# Altium schematic sheet SystemController.SchDoc(SystemController)
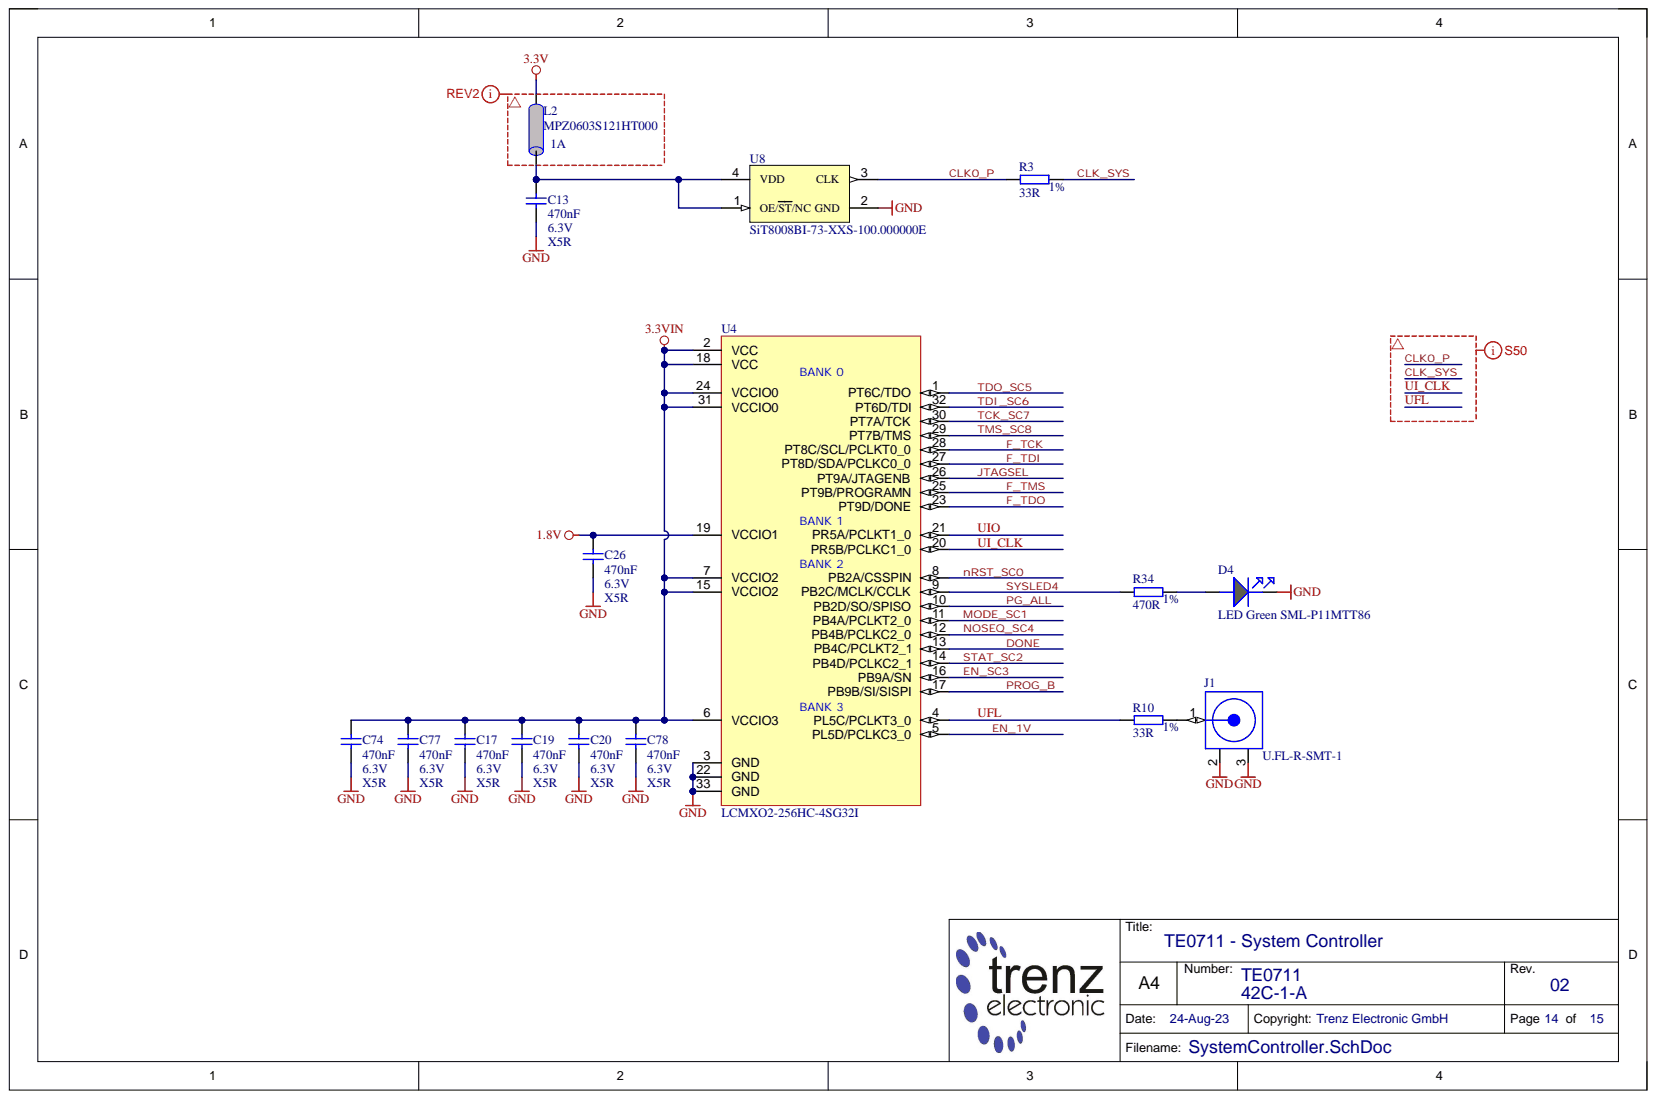
[diagram p0: SystemController.SchDoc]

## Parts
C13  470nF  Technology: X5R  Voltage: 6.3V  [CAPC0603X33N_V]
C17  470nF  Technology: X5R  Voltage: 6.3V  [CAPC0603X33N_V]
C19  470nF  Technology: X5R  Voltage: 6.3V  [CAPC0603X33N_V]
C20  470nF  Technology: X5R  Voltage: 6.3V  [CAPC0603X33N_V]
C26  470nF  Technology: X5R  Voltage: 6.3V  [CAPC0603X33N_V]
C74  470nF  Technology: X5R  Voltage: 6.3V  [CAPC0603X33N_V]
C77  470nF  Technology: X5R  Voltage: 6.3V  [CAPC0603X33N_V]
C78  470nF  Technology: X5R  Voltage: 6.3V  [CAPC0603X33N_V]
D4  LED Green SML-P11MTT86  Database Table Name: LED  Extra: SML-P11MTT86  [LEDC1006X25N_GREEN]
J1  U.FL-R-SMT-1  [U.FL-R-SMT-1]
L2  MPZ0603S121HT000  Voltage: 1A  [INDC0603X33N_V]
R3  33R  Tolerance: 1%  [RESC1005X40N_V]
R10  33R  Tolerance: 1%  [RESC1005X40N_V]
R34  470R  Tolerance: 1%  [RESC1005X40N_V]
U4  LCMXO2-256HC-4SG32I  [PQFN50P500X500X60-33N]
U8  SiT8008BI-73-XXS-100.000000E  Database Table Name: CLK  [2016]

## Nets
1.8V: C26.1 U4.19(VCCIO1)
3.3V: L2.1
3.3VIN: C17.2 C19.2 C20.2 C74.2 C77.2 C78.2 U4.2(VCC) U4.6(VCCIO3) U4.7(VCCIO2) U4.15(VCCIO2) U4.18(VCC) U4.24(VCCIO0) U4.31(VCCIO0)
GND: C13.2 C17.1 C19.1 C20.1 C26.2 C74.1 C77.1 C78.1 D4.K J1.2 J1.3 U4.3(GND) U4.22(GND) U4.33(GND) U8.2(GND)
CLK0_P: R3.1 U8.3(CLK)
CLK_SYS: R3.2
DONE: U4.13(PB4C/PCLKT2_1)
EN_1V: U4.5(PL5D/PCLKC3_0)
EN_SC3: U4.16(PB9A/SN)
F_TCK: U4.28(PT8C/SCL/PCLKT0_0)
F_TDI: U4.27(PT8D/SDA/PCLKC0_0)
F_TDO: U4.23(PT9D/DONE)
F_TMS: U4.25(PT9B/PROGRAMN)
JTAGSEL: U4.26(PT9A/JTAGENB)
MODE_SC1: U4.11(PB4A/PCLKT2_0)
NOSEQ_SC4: U4.12(PB4B/PCLKC2_0)
nRST_SC0: U4.8(PB2A/CSSPIN)
PG_ALL: U4.10(PB2D/SO/SPISO)
PROG_B: U4.17(PB9B/SI/SISPI)
STAT_SC2: U4.14(PB4D/PCLKC2_1)
SYSLED4: R34.2 U4.9(PB2C/MCLK/CCLK)
TCK_SC7: U4.30(PT7A/TCK)
TDI_SC6: U4.32(PT6D/TDI)
TDO_SC5: U4.1(PT6C/TDO)
TMS_SC8: U4.29(PT7B/TMS)
UFL: R10.1 U4.4(PL5C/PCLKT3_0)
UI_CLK: U4.20(PR5B/PCLKC1_0)
UIO: U4.21(PR5A/PCLKT1_0)
NetC13_1: C13.1 L2.2 U8.1(OE/ST/NC) U8.4(VDD)
NetD4_A: D4.A R34.1
NetJ1_1: J1.1 R10.2

## Library data of the parts
C13 C17 C19 C20 C26 C74 C77 C78 = 24921: KON 470nF 6.3V X5R 20%  SMD BF 0603 (0201); Database Table Name: C_0603_0201; Mixed: SMT; Preferred: Yes; Temperature-Range: -55/+85; Value: 470n; AN: 24921; Case: 0603 (0201); Component Kind: Standard; Library Name: TE.DbLib; Tolerance: 20%
D4 = 24211: Chip LED 0402 Green; H-ART: SML-P11MTT86R; Mixed: SMT; Preferred: Yes; Temperature-Range: -40/+85; AN: 24211; Case: 1005 (0402); Component Kind: Standard; Library Name: TE.DbLib; HelpURL: B:\AD\adlib\datasheet\SML-P1
J1 = 25605: Hirose Ultra Small Coaxial Connector; Database Table Name: CON_IO; Mixed: SMT; Temperature-Range: -40/+90; AN: 25605; Component Kind: Standard; Extra: U.FL-R-SMT-1; H-ART: U.FL-R-SMT-1; Library Name: TE.DbLib; Storage-Temperature: -30/+70; HelpURL: B:\AD\adlib\datasheet\U.FL
L2 = 30910: Ferritbead MPZ0603S121HT000; Database Table Name: L_0603_0201; Extra: 0,13 Ohm DC, 120 Ohm@100MHz. 1A , 25%; Mixed: SMT; Preferred: Yes; Temperature-Range: -55/+125 (Abs); Tolerance: 25%; Value: 120 Ohm; AN: 30910; Case: 0603 (0201); Component Kind: Standard; H-ART: MPZ0603S121HT000; Library Name: TE.DbLib; Storage-Temperature: -55/+125 (Abs); Technology: 0.13 Ohm; Trenz-Stock: Yes; HelpURL: b:\AD\adlib\datasheet\Murata\MPZ0603-H\
R3 R10 = 22770: WID SMD 33R 1% 0,063W BF 1005 (0402); Database Table Name: R_1005_0402; H-ART: RC0402FR-0733RL; Mixed: SMT; Preferred: Yes; Value: 33; Voltage: 0.063W; AN: 22770; Case: 1005 (0402); Component Kind: Standard; Library Name: TE.DbLib; Technology: TK100
R34 = 22769: WID SMD 470R 1% 0,063W BF 1005 (0402); Database Table Name: R_1005_0402; Mixed: SMT; Preferred: Yes; Value: 470; Voltage: 0.063W; AN: 22769; Case: 1005 (0402); Component Kind: Standard; Library Name: TE.DbLib; Technology: TK100
U4 = 26395: Database Table Name: PROG_LOGIC; Extra: Lattice FPGA; Mixed: SMT; Preferred: Yes; Temperature-Range: -40/+100 (Tj); AN: 26395; Case: QFN32; Component Kind: Standard; Description: LCMXO2-256HC-4SG32I; H-ART: LCMXO2-256HC-4SG32I; Library Name: TE.DbLib; Storage-Temperature: -55/+125 (Abs); Trenz-Stock: Yes; HelpURL: B:\AD\adlib\datasheet\MachXO2
U8 = 25961: SiT8008BI-73-XXS-100.000000E MEMS Oszillator 100MHz; Extra: 2,25 - 3,63V , -40° C - 85° C, +/-50 ppm, 2 x1,6 mm; Mixed: SMT; Preferred: Yes; Temperature-Range: -40/+85; Value: 100M; AN: 25961; Case: 2.0 x 1.6mm; Component Kind: Standard; H-ART: SiT8008BI-73-XXS-100.000000E; Library Name: TE.DbLib; Trenz-Stock: Yes; HelpURL: B:\AD\adlib\datasheet\SiT8008
